# Altium schematic sheet GroveHeadphoneAmp.SchDoc
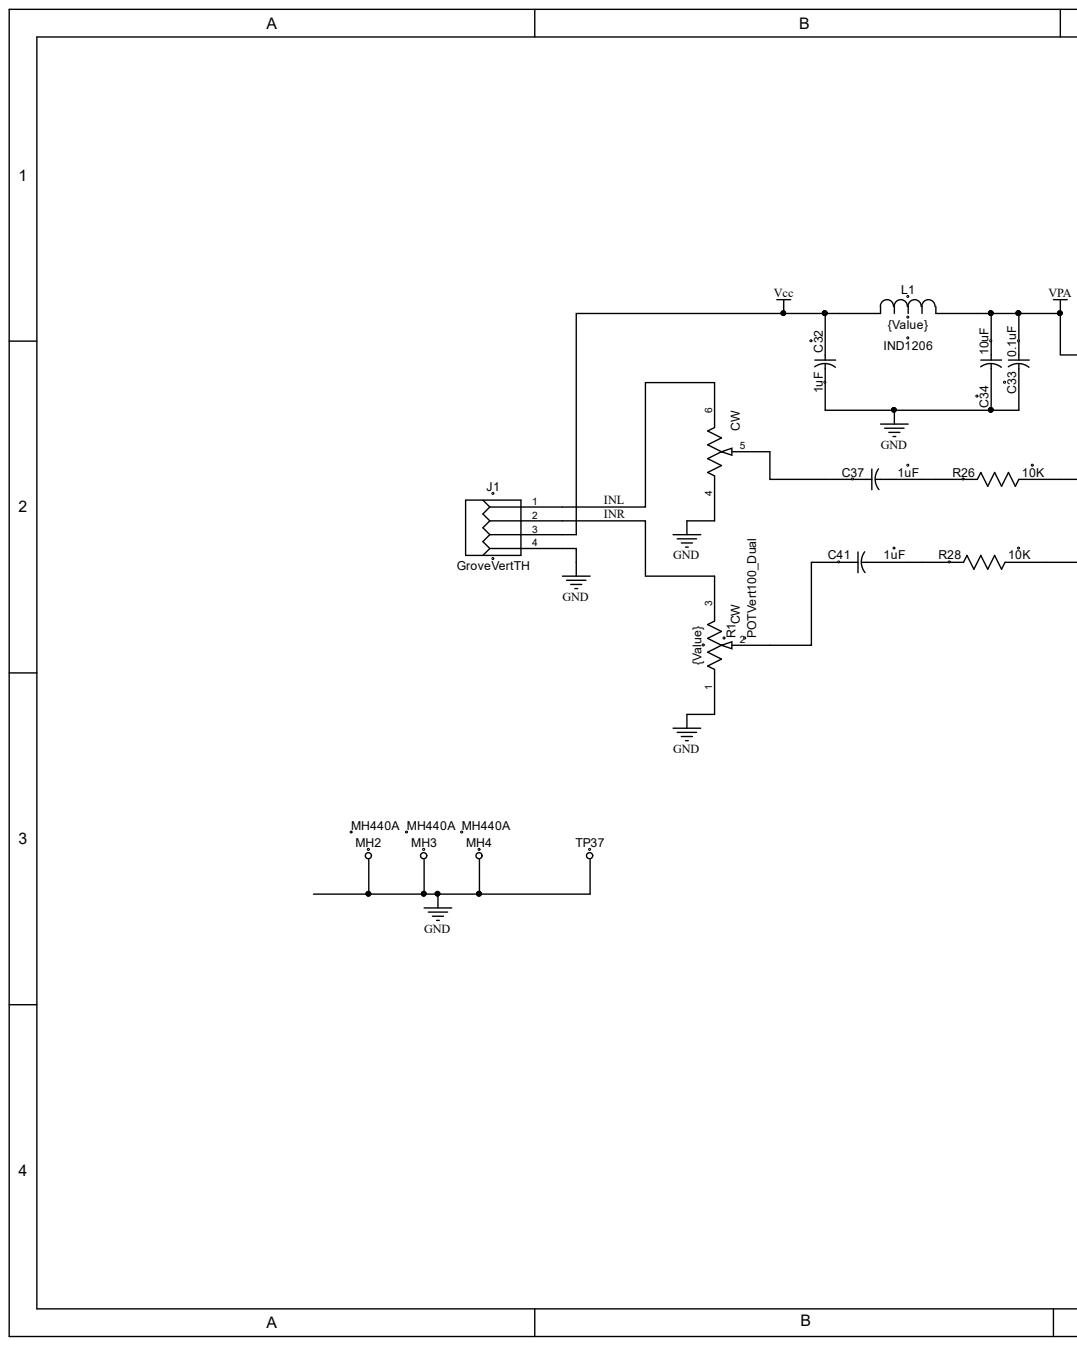
[diagram p0: GroveHeadphoneAmp.SchDoc, left]
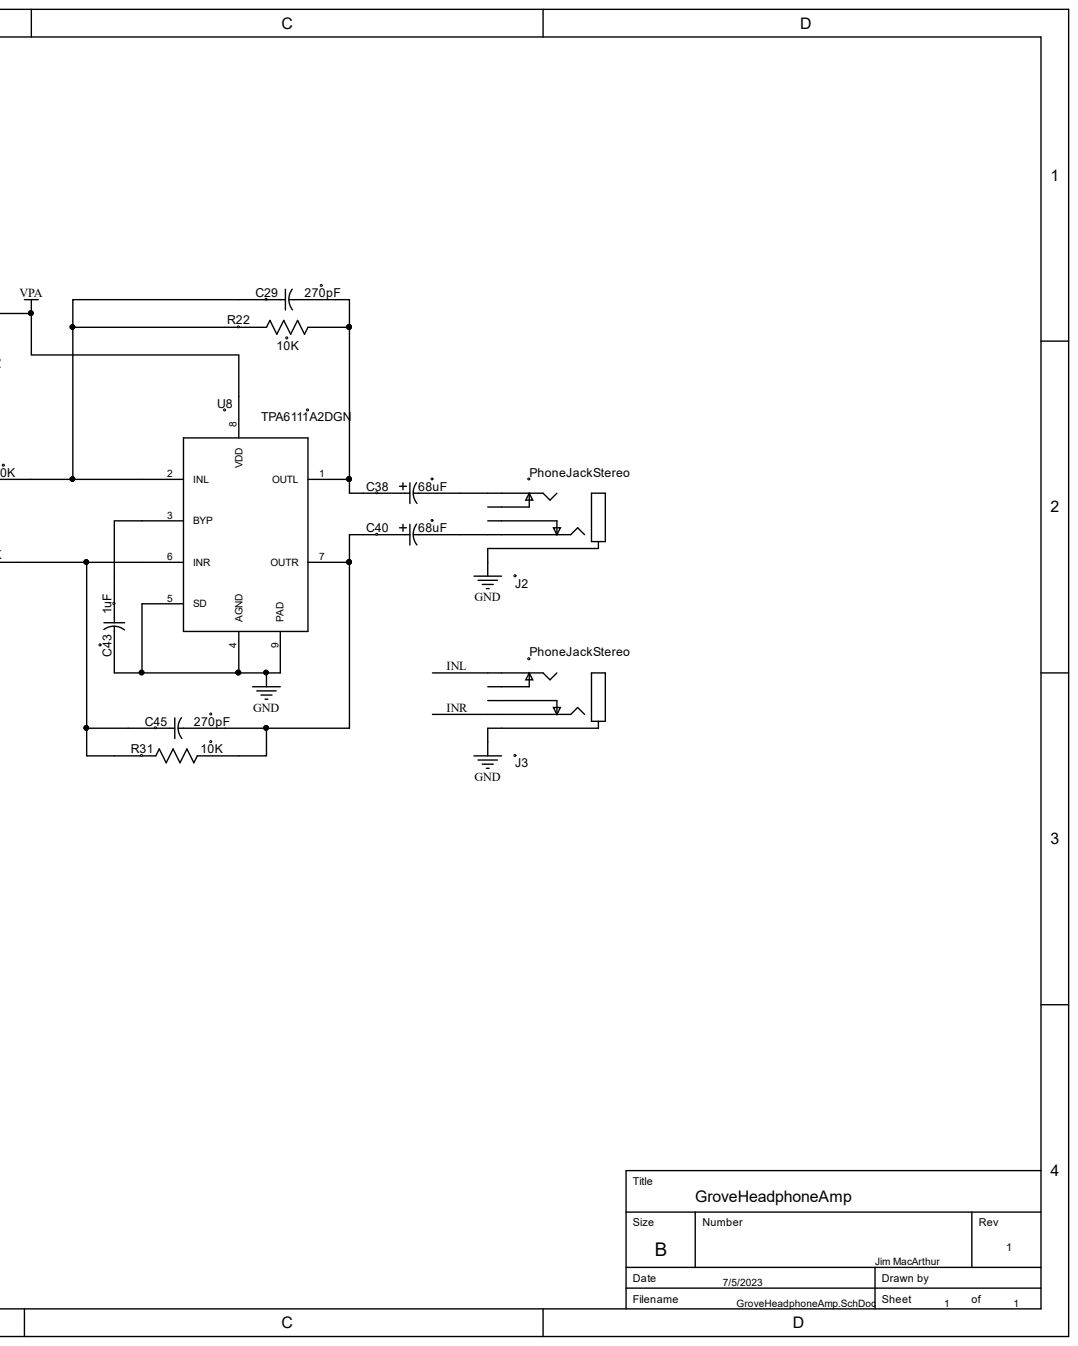
[diagram p1: GroveHeadphoneAmp.SchDoc, right]

## Parts
C29  value 270pF  [RC0805_CAP0805(Primary)]  (hidden comment: CAP0805)
C32  value 1uF  [RC0805_CAP0805(Primary)]  (hidden comment: CAP0805)
C33  value 0.1uF  [RC0603]  (hidden comment: CAP0603)
C34  value 10uF  [RC1210_CAP1210(Primary)]  (hidden comment: CAP1210)
C37  value 1uF  [CAP200_CAP200(Primary)]  (hidden comment: CAP200)
C38  value 68uF  [CAP100RP_CAP100RP(Primary)]  (hidden comment: CAP100RP)
C40  value 68uF  [CAP100RP_CAP100RP(Primary)]  (hidden comment: CAP100RP)
C41  value 1uF  [CAP200_CAP200(Primary)]  (hidden comment: CAP200)
C43  value 1uF  [RC0805_CAP0805(Primary)]  (hidden comment: CAP0805)
C45  value 270pF  [RC0805_CAP0805(Primary)]  (hidden comment: CAP0805)
J1  GroveVertTH  [GroveVertTH]
J2  PhoneJackStereo  [PhoneJackStereo]
J3  PhoneJackStereo  [PhoneJackStereo]
L1  IND1206  value {Value}  [RC1206_RES1206(Primary)]
MH2  MH440A  [MH440A]
MH3  MH440A  [MH440A]
MH4  MH440A  [MH440A]
R1  value {Value}  [POTV112]  (hidden comment: POTVert100_Dual)
R22  value 10K  [RC0805_RES0805(Primary)]  (hidden comment: RES0805)
R26  value 10K  [RC0805_RES0805(Primary)]  (hidden comment: RES0805)
R28  value 10K  [RC0805_RES0805(Primary)]  (hidden comment: RES0805)
R31  value 10K  [RC0805_RES0805(Primary)]  (hidden comment: RES0805)
TP37  TP52  [TP52]  (value not drawn)
U8  TPA6111A2DGN  [MS8 - EPAD]

## Nets
GND: C32.B C33.1 C34.B C43.B J1.4 J2.1 J3.1 MH2.1 MH3.1 MH4.1 R1.1 R1.4 TP37.1 U8.4(AGND) U8.5(SD) U8.9(PAD)
VCC: C32.A J1.3 L1.B
VPA: C33.2 C34.A L1.A U8.8(VDD)
INL: J1.1 J3.2 R1.6
INR: J1.2 J3.3 R1.3
NetC29_A: C29.A R22.B R26.A U8.2(INL)
NetC29_B: C29.B C38.PLUS R22.A U8.1(OUTL)
NetC37_A: C37.A R1.5
NetC37_B: C37.B R26.B
NetC38_MINUS: C38.MINUS J2.2
NetC40_MINUS: C40.MINUS J2.3
NetC40_PLUS: C40.PLUS C45.B R31.A U8.7(OUTR)
NetC41_A: C41.A R1.2
NetC41_B: C41.B R28.B
NetC43_A: C43.A U8.3(BYP)
NetC45_A: C45.A R28.A R31.B U8.6(INR)

Not connected: J2 4 5; J3 4 5

## Library data of the parts
C38 C40 = CAP100RP: Radial Polarized Capacitor; Component Kind: Standard; Library Name: JMAC.IntLib
J1 = GroveVertTH: vertical thru-hole Grove connector; Component Kind: Standard; Library Name: JMAC.IntLib
J2 J3 = PhoneJackStereo: SJ1-3535; Value: {Value}; Component Kind: Standard; Library Name: JMAC.IntLib
MH2-MH4 = MH440A: Value: {Value}; Component Kind: Standard; Description: MH440A; Library Name: JMAC.IntLib
R1 = POTVert100_Dual: Dual Vertical pot 100 mil pin spacing PTV112-4; Component Kind: Standard; Library Name: JMAC.IntLib
U8 = TPA6111A2DGN: headphone amp, compat w LM4881; Component Kind: Standard; Library Name: JMAC.IntLib
C29 C32 C43 C45 = CAP0805: Component Kind: Standard; Description: CAP0805; Library Name: JMAC.IntLib
C33 = CAP0603: Component Kind: Standard; Description: CAP0603; Library Name: JMAC.IntLib
C34 = CAP1210: Component Kind: Standard; Description: CAP1210; Library Name: JMAC.IntLib
C37 C41 = CAP200: Component Kind: Standard; Description: CAP200; Library Name: JMAC.IntLib
L1 = IND1206: Component Kind: Standard; Description: IND1206; Library Name: JMAC.IntLib
R22 R26 R28 R31 = RES0805: Component Kind: Standard; Description: RES0805; Library Name: JMAC.IntLib
TP37 = TP52: Component Kind: Standard; Description: TP52; Library Name: JMAC.IntLib
